# Altium schematic sheet PL_GETH_PHY.SchDoc
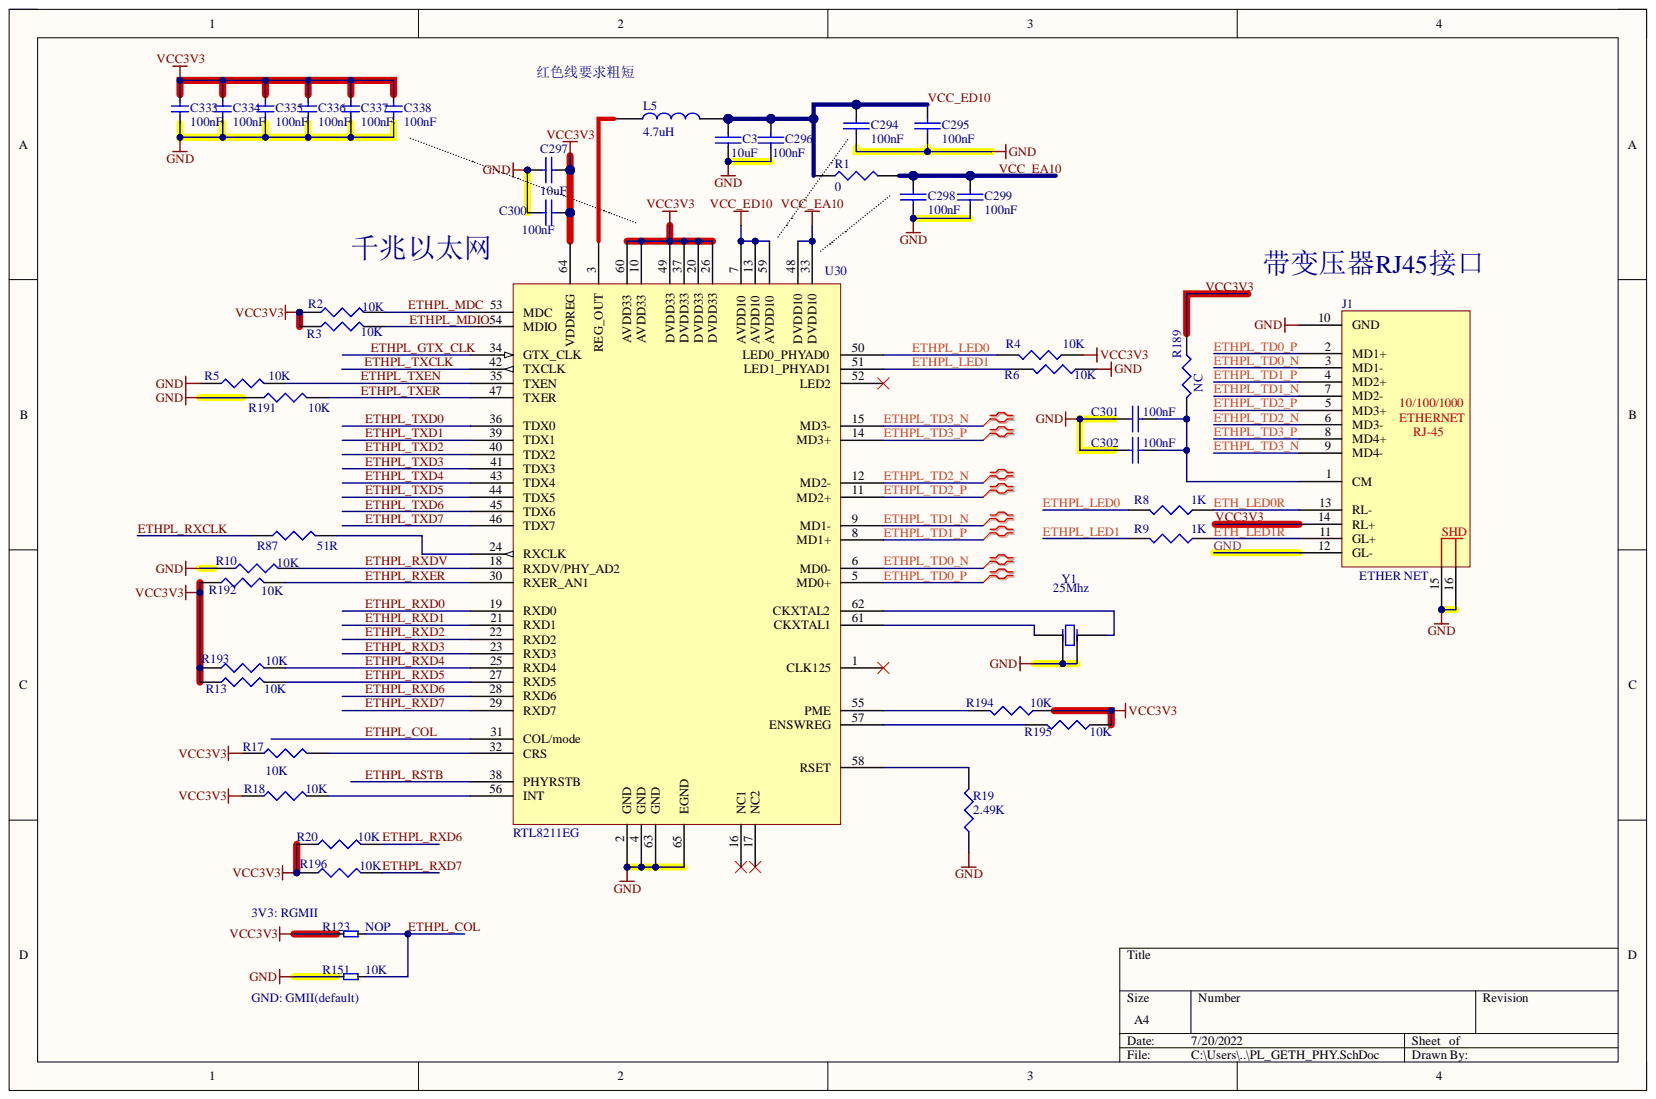
[diagram p0: PL_GETH_PHY.SchDoc]

## Parts
C3  10uF  [C0603]
C294  100nF  [C0201]
C295  100nF  [C0201]
C296  100nF  [C0201]
C297  10uF  [C0603]
C298  100nF  [C0201]
C299  100nF  [C0201]
C300  100nF  [C0201]
C301  100nF  [C0201]
C302  100nF  [C0201]
C333  100nF  [C0201]
C334  100nF  [C0201]
C335  100nF  [C0201]
C336  100nF  [C0201]
C337  100nF  [C0201]
C338  100nF  [C0201]
J1  ETHER NET  [RJ45_2021]
L5  4.7uH  [L3X3MM]
R1  0  [R0201]
R2  10K  [R0201]
R3  10K  [R0201]
R4  10K  [R0201]
R5  10K  [R0201]
R6  10K  [R0201]
R8  1K  [R0201]
R9  1K  [R0201]
R10  10K  [R0201]
R13  10K  [R0201]
R17  10K  [R0201]
R18  10K  [R0201]
R19  2.49K  [R0201]
R20  10K  [R0201]
R87  51R  [R0201]
R123  NOP  [R0201]
R151  10K  [R0201]
R189  NC  [R0201]
R191  10K  [R0201]
R192  10K  [R0201]
R193  10K  [R0201]
R194  10K  [R0201]
R195  10K  [R0201]
R196  10K  [R0201]
U30  RTL8211EG  [QFN64]
Y1  25Mhz  [OSC_3225]

## Nets
ETH_LED0R: J1.13(RL-) R8.1
ETH_LED1R: J1.11(GL+) R9.1
ETHPL_COL: R123.1 R151.1 U30.31(COL/mode)
ETHPL_GTX_CLK: U30.34(GTX_CLK)
ETHPL_LED0: R4.2 R8.2 U30.50(LED0_PHYAD0)
ETHPL_LED1: R6.2 R9.2 U30.51(LED1_PHYAD1)
ETHPL_MDC: R2.2 U30.53(MDC)
ETHPL_MDIO: R3.2 U30.54(MDIO)
ETHPL_RSTB: U30.38(PHYRSTB)
ETHPL_RXCLK: R87.1
ETHPL_RXD0: U30.19(RXD0)
ETHPL_RXD1: U30.21(RXD1)
ETHPL_RXD2: U30.22(RXD2)
ETHPL_RXD3: U30.23(RXD3)
ETHPL_RXD4: R193.2 U30.25(RXD4)
ETHPL_RXD5: R13.2 U30.27(RXD5)
ETHPL_RXD6: R20.2 U30.28(RXD6)
ETHPL_RXD7: R196.2 U30.29(RXD7)
ETHPL_RXDV: R10.2 U30.18(RXDV/PHY_AD2)
ETHPL_RXER: R192.2 U30.30(RXER_AN1)
ETHPL_TD0_N: J1.3(MD1-) U30.6(MD0-)
ETHPL_TD0_P: J1.2(MD1+) U30.5(MD0+)
ETHPL_TD1_N: J1.7(MD2-) U30.9(MD1-)
ETHPL_TD1_P: J1.4(MD2+) U30.8(MD1+)
ETHPL_TD2_N: J1.6(MD3-) U30.12(MD2-)
ETHPL_TD2_P: J1.5(MD3+) U30.11(MD2+)
ETHPL_TD3_N: J1.9(MD4-) U30.15(MD3-)
ETHPL_TD3_P: J1.8(MD4+) U30.14(MD3+)
ETHPL_TXCLK: U30.42(TXCLK)
ETHPL_TXD0: U30.36(TDX0)
ETHPL_TXD1: U30.39(TDX1)
ETHPL_TXD2: U30.40(TDX2)
ETHPL_TXD3: U30.41(TDX3)
ETHPL_TXD4: U30.43(TDX4)
ETHPL_TXD5: U30.44(TDX5)
ETHPL_TXD6: U30.45(TDX6)
ETHPL_TXD7: U30.46(TDX7)
ETHPL_TXEN: R5.2 U30.35(TXEN)
ETHPL_TXER: R191.2 U30.47(TXER)
GND: C3.2 C294.2 C295.2 C296.2 C297.2 C298.2 C299.2 C300.2 C301.2 C302.2 C333.1 C334.1 C335.1 C336.1 C337.1 C338.1 J1.10(GND) J1.12(GL-) J1.15 J1.16 R5.1 R6.1 R10.1 R19.1 R151.2 R191.1 U30.2(GND) U30.4(GND) U30.63(GND) U30.65(EGND) Y1.2 Y1.4
VCC3V3: C297.1 C300.1 C333.2 C334.2 C335.2 C336.2 C337.2 C338.2 J1.14(RL+) R2.1 R3.1 R4.1 R13.1 R17.1 R18.1 R20.1 R123.2 R189.1 R192.1 R193.1 R194.1 R195.1 R196.1 U30.10(AVDD33) U30.20(DVDD33) U30.26(DVDD33) U30.37(DVDD33) U30.49(DVDD33) U30.60(AVDD33) U30.64(VDDREG)
VCC_EA10: C298.1 C299.1 R1.2 U30.33(DVDD10) U30.48(DVDD10)
VCC_ED10: C3.1 C294.1 C295.1 C296.1 L5.2 R1.1 U30.7(AVDD10) U30.13(AVDD10) U30.59(AVDD10)
NetC301_1: C301.1 C302.1 J1.1(CM) R189.2
NetL5_1: L5.1 U30.3(REG_OUT)
NetR17_2: R17.2 U30.32(CRS)
NetR18_2: R18.2 U30.56(INT)
NetR19_2: R19.2 U30.58(RSET)
NetR87_2: R87.2 U30.24(RXCLK)
NetR194_2: R194.2 U30.55(PME)
NetR195_2: R195.2 U30.57(ENSWREG)
NetU30_61: U30.61(CKXTAL1) Y1.1
NetU30_62: U30.62(CKXTAL2) Y1.3

Not connected: U30 1(CLK125) 16(NC1) 17(NC2) 52(LED2)

## Library data of the parts
C3 C294-C302 = CAP: Capacitor; Component Kind: Standard
C333-C338 = C: Capacitor; Component Kind: Standard; Library Name: 电容-SCH.LIB
J1 = 1840750-7: Component Kind: Standard; Library Name: ezfpga.SCHLIB
L5 = INDUCTOR1: Component Kind: Standard
R1-R6 R8-R10 R13 R17-R20 R87 R189 R191-R196 = RES1: Component Kind: Standard
R123 R151 = RES2: Component Kind: Standard; Library Name: 电阻-SCH.LIB
U30 = RTL8211DG: Component Kind: Standard; Library Name: ezfpga.SCHLIB
Y1 = SMD-3225_4P  25MHZ 12PF 10PPM: 无源4P贴片晶体3225; Component Kind: Standard; ComponentLink1Description: 供应商链接; ComponentLink1URL: http://www.szlcsc.com/product/details_9526.html; Package: SMD-3225_4P; Supplier: LC; SuppliersPartNumber: C9006; Library Name: ATE公司集成库.IntLib
